# Altium schematic sheet STC15_U1.SchDoc(U_STC15_U1)
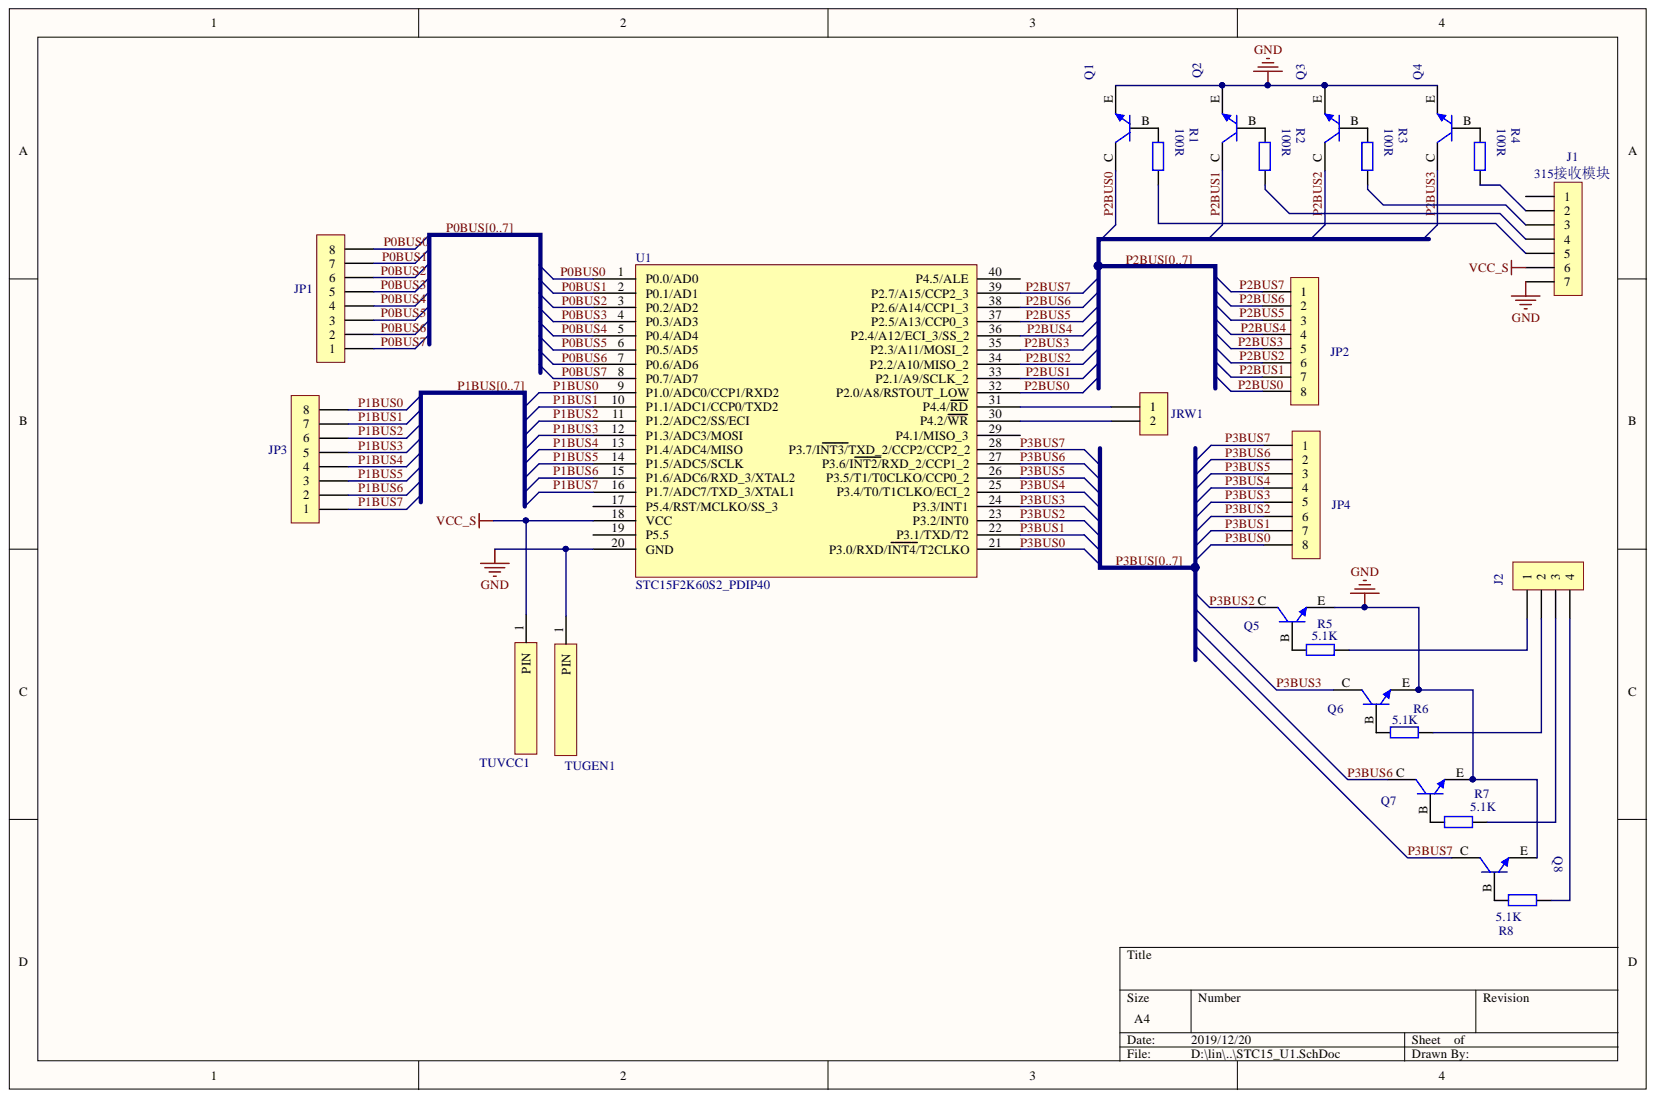
[diagram p0: STC15_U1.SchDoc]

## Parts
J1  315接收模块  [CON7P-2R54-TH]
J2  61300411121  [CON4P-2R54-TH]  (value not drawn)
JP1  SSW-108-22-L-S-VS  [CON8R-2R54-TH]  (value not drawn)
JP2  SSW-108-22-L-S-VS  [CON8R-2R54-TH]  (value not drawn)
JP3  SSW-108-22-L-S-VS  [CON8R-2R54-TH]  (value not drawn)
JP4  SSW-108-22-L-S-VS  [CON8R-2R54-TH]  (value not drawn)
JRW1  62000211121  [CON2P-2R0-TH]  (value not drawn)
Q1  2N3904  [TO-92-3-EBC-1R2]  (value not drawn)
Q2  2N3904  [TO-92-3-EBC-1R2]  (value not drawn)
Q3  2N3904  [TO-92-3-EBC-1R2]  (value not drawn)
Q4  2N3904  [TO-92-3-EBC-1R2]  (value not drawn)
Q5  2N3904  [TO-92-3-EBC-1R2]  (value not drawn)
Q6  2N3904  [TO-92-3-EBC-1R2]  (value not drawn)
Q7  2N3904  [TO-92-3-EBC-1R2]  (value not drawn)
Q8  2N3904  [TO-92-3-EBC-1R2]  (value not drawn)
R1  100R  [RY0R25W]
R2  100R  [RY0R25W]
R3  100R  [RY0R25W]
R4  100R  [RY0R25W]
R5  5.1K  [RY0R25W]
R6  5.1K  [RY0R25W]
R7  5.1K  [RY0R25W]
R8  5.1K  [RY0R25W]
TUGEN1  T？  [铺铜条]
TUVCC1  T？  [铺铜条]
U1  STC15F2K60S2_PDIP40  [DIP40]

## Nets
GND: J1.7 Q1.E Q2.E Q3.E Q4.E Q5.E Q6.E Q7.E Q8.E TUGEN1.1 U1.20(GND)
VCC_S: J1.6 TUVCC1.1 U1.18(VCC)
P0BUS0: JP1.8 U1.1(P0.0/AD0)  [also labelled P0BUS]
P0BUS1: JP1.7 U1.2(P0.1/AD1)  [also labelled P0BUS]
P0BUS2: JP1.6 U1.3(P0.2/AD2)  [also labelled P0BUS]
P0BUS3: JP1.5 U1.4(P0.3/AD3)  [also labelled P0BUS]
P0BUS4: JP1.4 U1.5(P0.4/AD4)  [also labelled P0BUS]
P0BUS5: JP1.3 U1.6(P0.5/AD5)  [also labelled P0BUS]
P0BUS6: JP1.2 U1.7(P0.6/AD6)  [also labelled P0BUS]
P0BUS7: JP1.1 U1.8(P0.7/AD7)  [also labelled P0BUS]
P1BUS0: JP3.8 U1.9(P1.0/ADC0/CCP1/RXD2)  [also labelled P1BUS]
P1BUS1: JP3.7 U1.10(P1.1/ADC1/CCP0/TXD2)  [also labelled P1BUS]
P1BUS2: JP3.6 U1.11(P1.2/ADC2/SS/ECI)  [also labelled P1BUS]
P1BUS3: JP3.5 U1.12(P1.3/ADC3/MOSI)  [also labelled P1BUS]
P1BUS4: JP3.4 U1.13(P1.4/ADC4/MISO)  [also labelled P1BUS]
P1BUS5: JP3.3 U1.14(P1.5/ADC5/SCLK)  [also labelled P1BUS]
P1BUS6: JP3.2 U1.15(P1.6/ADC6/RXD_3/XTAL2)  [also labelled P1BUS]
P1BUS7: JP3.1 U1.16(P1.7/ADC7/TXD_3/XTAL1)  [also labelled P1BUS]
P2BUS0: JP2.8 Q1.C U1.32(P2.0/A8/RSTOUT_LOW)  [also labelled P2BUS]
P2BUS1: JP2.7 Q2.C U1.33(P2.1/A9/SCLK_2)  [also labelled P2BUS]
P2BUS2: JP2.6 Q3.C U1.34(P2.2/A10/MISO_2)  [also labelled P2BUS]
P2BUS3: JP2.5 Q4.C U1.35(P2.3/A11/MOSI_2)  [also labelled P2BUS]
P2BUS4: JP2.4 U1.36(P2.4/A12/ECI_3/SS_2)  [also labelled P2BUS]
P2BUS5: JP2.3 U1.37(P2.5/A13/CCP0_3)  [also labelled P2BUS]
P2BUS6: JP2.2 U1.38(P2.6/A14/CCP1_3)  [also labelled P2BUS]
P2BUS7: JP2.1 U1.39(P2.7/A15/CCP2_3)  [also labelled P2BUS]
P3BUS0: JP4.8 U1.21(P3.0/RXD/INT4/T2CLKO)  [also labelled P3BUS]
P3BUS1: JP4.7 U1.22(P3.1/TXD/T2)  [also labelled P3BUS]
P3BUS2: JP4.6 Q5.C U1.23(P3.2/INT0)  [also labelled P3BUS]
P3BUS3: JP4.5 Q6.C U1.24(P3.3/INT1)  [also labelled P3BUS]
P3BUS4: JP4.4 U1.25(P3.4/T0/T1CLKO/ECI_2)  [also labelled P3BUS]
P3BUS5: JP4.3 U1.26(P3.5/T1/T0CLKO/CCP0_2)  [also labelled P3BUS]
P3BUS6: JP4.2 Q7.C U1.27(P3.6/INT2/RXD_2/CCP1_2)  [also labelled P3BUS]
P3BUS7: JP4.1 Q8.C U1.28(P3.7/INT3/TXD_2/CCP2/CCP2_2)  [also labelled P3BUS]
NetJ1_2: J1.2 R4.2
NetJ1_3: J1.3 R3.2
NetJ1_4: J1.4 R2.2
NetJ1_5: J1.5 R1.2
NetJ2_1: J2.1 R5.2
NetJ2_2: J2.2 R6.2
NetJ2_3: J2.3 R7.2
NetJ2_4: J2.4 R8.2
NetJRW1_1: JRW1.1 U1.31(P4.4/RD)
NetJRW1_2: JRW1.2 U1.30(P4.2/WR)
NetQ1_B: Q1.B R1.1
NetQ2_B: Q2.B R2.1
NetQ3_B: Q3.B R3.1
NetQ4_B: Q4.B R4.1
NetQ5_B: Q5.B R5.1
NetQ6_B: Q6.B R6.1
NetQ7_B: Q7.B R7.1
NetQ8_B: Q8.B R8.1

Not connected: J1 1; U1 17(P5.4/RST/MCLKO/SS_3) 19(P5.5) 29(P4.1/MISO_3) 40(P4.5/ALE)

## Library data of the parts
J1 = 102NL-00032BV.01: DIP,封装脚距2.54mm,180度,1x7PIN,黑色; Allow Altenative Part: Y; Approved: YES; Categories: 连接器; COMPONENTLINK 1 DESCRIPTION: GetDatasheet; Database Table Name: Connector; Modify time: 2015年07月10号; Remark: Verified by CRD（赖程奇） check list V1.0; serial number: 165; Value: 61300711121; Category: 单排针; Component Kind: Standard; COMPONENTLINK 1 URL: http://katalog.we-online.de/en/em/620_0xx_111_21/620_032_111_21?m=n&sp=http%3A%2F%2Fwww.we-online.com%2Fweb%2Fen%2Fwuerth_elektronik%2Fsearchpage.php%3Fsearch%3D62003211121; Library Name: IA-04.DbLib; MOQ: 500; Mounting Type: ThroughHole; PACKAGE: Bulk; Part Number: 102NL-00032BV.01; Pricing 1: 2.05; Supplier 1: wurth; Supplier Part Number 1: 61300711121
J2 = 102NL-00004BV.01: DIP,封装脚距2.54mm,180度,1x4PIN,黑色; Allow Altenative Part: Y; Approved: YES; Categories: 连接器; COMPONENTLINK 1 DESCRIPTION: GetDatasheet; Database Table Name: Connector; Modify time: 2015年07月10号; Remark: Verified by CRD（赖程奇） check list V1.0; serial number: 603; Category: 单排针; Component Kind: Standard; COMPONENTLINK 1 URL: http://katalog.we-online.de/en/em/620_0xx_111_21/620_032_111_21?m=n&sp=http%3A%2F%2Fwww.we-online.com%2Fweb%2Fen%2Fwuerth_elektronik%2Fsearchpage.php%3Fsearch%3D62003211121; Library Name: IA-04.DbLib; MOQ: 500; Mounting Type: ThroughHole; PACKAGE: Bulk; Part Number: 102NL-00004BV.01; Pricing 1: 1.21; Supplier 1: wurth; Supplier Part Number 1: 61300411121; Value: 61300411121
JP1-JP4 = 102NM-00001BF.01: DIP,封装脚距2.54mm,180度,1x8PIN,塑高/针长8.5/4.93mm,黑色; Allow Altenative Part: Y; Approved: YES; Categories: 连接器; COMPONENTLINK 1 DESCRIPTION: GetDatasheet; Database Table Name: Connector; Modify time: 2015年11月06号; Remark: Verified by CRD（赖程奇） check list V1.0; serial number: 158; Category: 单排母; Component Kind: Standard; COMPONENTLINK 1 URL: https://wwws.samtec.com/; Library Name: IA-04.DbLib; MOQ: 100; Mounting Type: ThroughHole; PACKAGE: Bulk; Part Number: 102NM-00001BF.01; Pricing 1: 1.67; Supplier 1: Samtec; Supplier Part Number 1: SSW-108-22-L-S-VS; Value: SSW-108-22-L-S-VS
JRW1 = 102NL-00015BV.01: DIP,封装脚距2mm,180度,1x2PIN,黑色; Allow Altenative Part: Y; Approved: YES; Categories: 连接器; COMPONENTLINK 1 DESCRIPTION: GetDatasheet; Database Table Name: Connector; Modify time: 2015年07月10号; Remark: Verified by CRD（赖程奇） check list V1.0; serial number: 164; Category: 单排针; Component Kind: Standard; COMPONENTLINK 1 URL: http://katalog.we-online.de/en/em/620_0xx_111_21/620_032_111_21?m=n&sp=http%3A%2F%2Fwww.we-online.com%2Fweb%2Fen%2Fwuerth_elektronik%2Fsearchpage.php%3Fsearch%3D62003211121; Library Name: IA-04.DbLib; MOQ: 500; Mounting Type: ThroughHole; PACKAGE: Bulk; Part Number: 102NL-00015BV.01; Pricing 1: .98; Supplier 1: wurth; Supplier Part Number 1: 62000211121; Value: 62000211121
Q1-Q8 = 102GN-00009BA.01: DIP,封装形式TO-92-3,耐压值40V,额定电流200mA,耗散功率625mW; Allow Altenative Part: Y; Approved: YES; Categories: 三极管; COMPONENTLINK 1 DESCRIPTION: OpenDatasheet; Database Table Name: Triode; Modify time: 2015年08月08号; Rated Current: 0.2A; Rated Power: 625mW; Rated Voltage: 40V; Remark: Verified by BU1（吴明徽）check list V1.0; serial number: 1; Category: NPN三极管; Component Kind: Standard; COMPONENTLINK 1 URL: http://media.digikey.com/pdf/Data%20Sheets/ON%20Semiconductor%20PDFs/2N3903,%202N3904%20Rev4.pdf; Library Name: IA-04.DbLib; MOQ: 1000; Mounting Type: SurfaceMount; PACKAGE: Tape&Reel; Part Number: 102GN-00009BA.01; Pricing 1: .17; Size: TO-92-3; Supplier 1: ON; Supplier Part Number 1: 2N3904; Value: 2N3904
R1-R4 = 102RO-00040BS.01: DIP,阻值100R,精度±1%,6.1x2.29mm,额定功率1/4W; Allow Altenative Part: Y; Approved: YES; Categories: 电阻; COMPONENTLINK 1 DESCRIPTION: OpenDatasheet; Database Table Name: RES_ESP; Modify time: 2015年07月10号; Rated Power: 1/4W; Remark: Verified by BU3（吴明徽）check list V1.0; serial number: 15; Tolerance: ±1%; Category: 功率电阻; Component Kind: Standard; COMPONENTLINK 1 URL: http://www.vishay.com/docs/31027/cmfmil.pdf; Library Name: IA-04.DbLib; MOQ: 5000; Mounting Type: ThroughHole; PACKAGE: Bulk; Part Number: 102RO-00040BS.01; Pricing 1: 1.44; Size: 2.29x7.37mm; Supplier 1: Vishay; Supplier Part Number 1: CMF55100R00FKRE39; Value: 100R
R5-R8 = 102RO-00048BS.01: DIP,阻值5.1K,精度±1%,6.2x2.29mm,额定功率1/4W; Allow Altenative Part: Y; Approved: YES; Categories: 电阻; COMPONENTLINK 1 DESCRIPTION: OpenDatasheet; Database Table Name: RES_ESP; Modify time: 2015年07月10号; Rated Power: 1/4W; Remark: Verified by BU3（吴明徽）check list V1.0; serial number: 10; Tolerance: ±1%; Category: 功率电阻; Component Kind: Standard; COMPONENTLINK 1 URL: http://www.vishay.com/docs/31015/ccf55.pdf; Library Name: IA-04.DbLib; MOQ: 5000; Mounting Type: ThroughHole; PACKAGE: Bulk; Part Number: 102RO-00048BS.01; Pricing 1: 4.48; Size: 2.29x7.5mm; Supplier 1: Vishay; Supplier Part Number 1: CCF555K10FKE36; Value: 5.1K
TUGEN1 TUVCC1 = 铺铜条: 2mm*20的铜条用于焊接; Component Kind: Standard; Library Name: KF接口.SchLib
U1 = STC15F2K60S2_PDIP40: Component Kind: Standard; Library Name: stcmcu_altium1819.SchLib
